# Altium schematic sheet mcu_v1.SchDoc(mcu_v1)
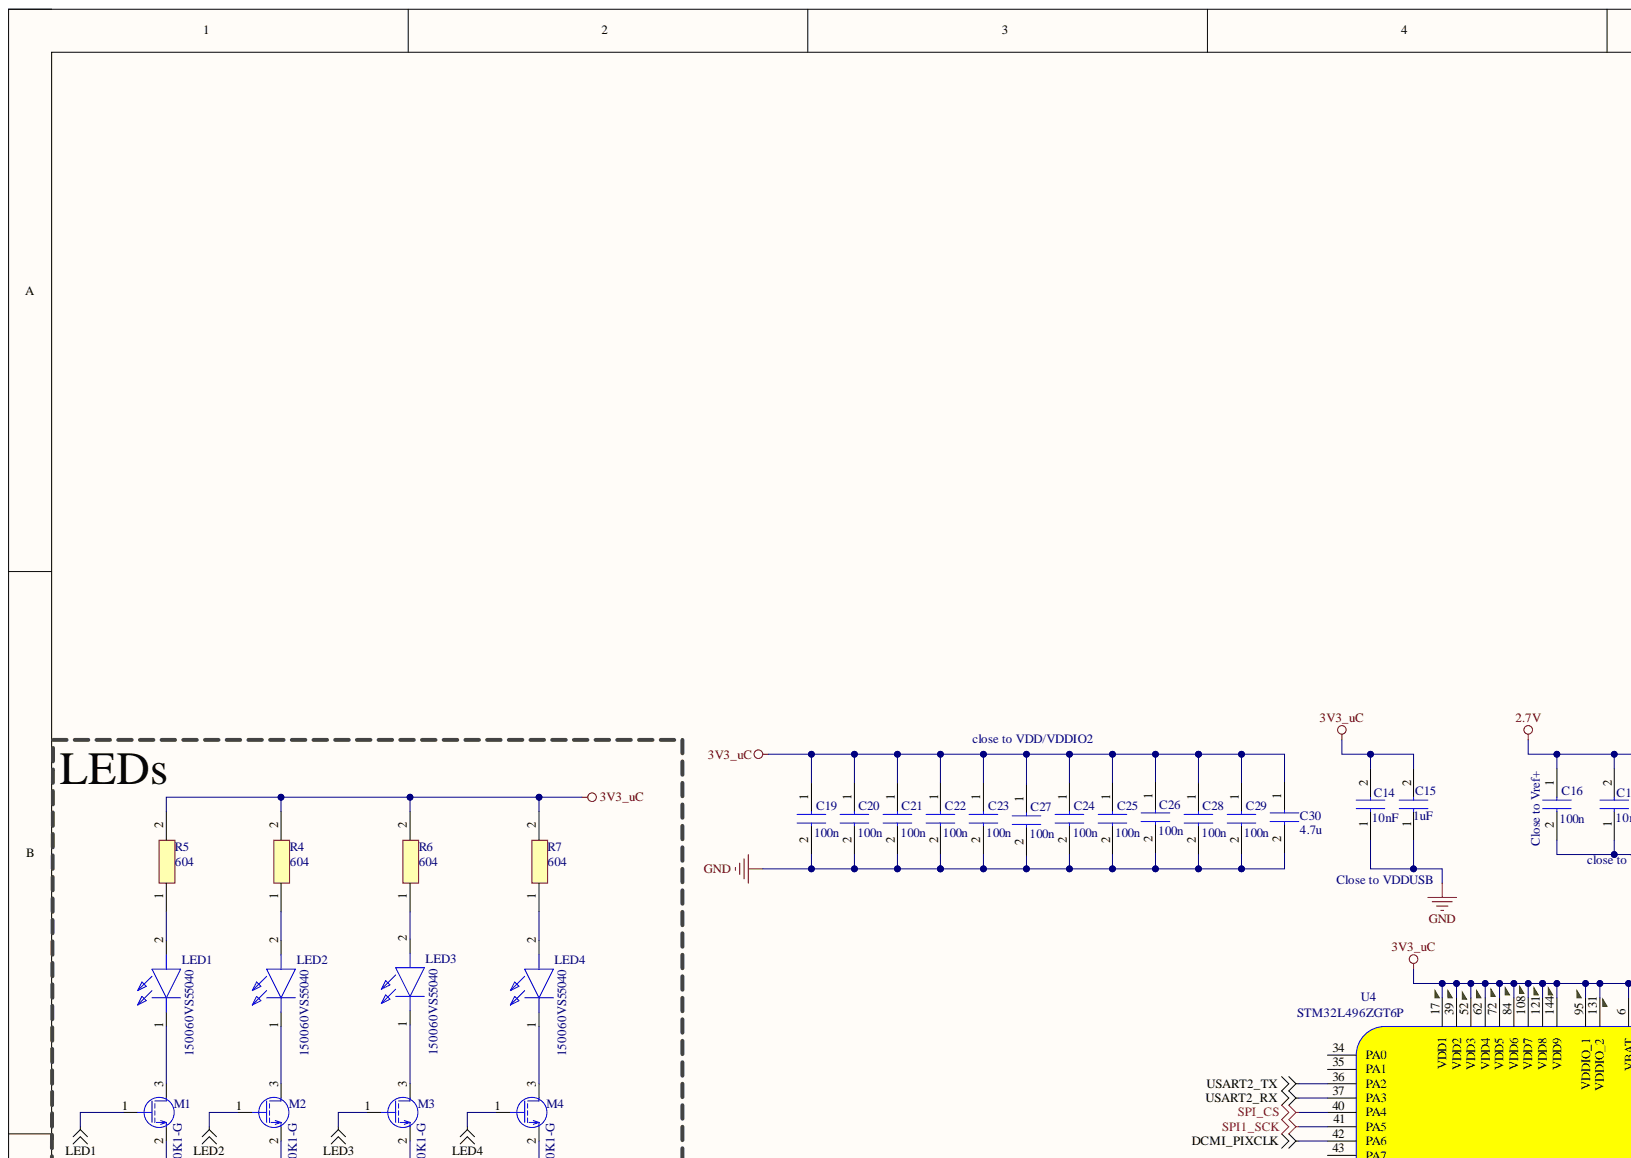
[diagram p0: mcu_v1.SchDoc, top left]
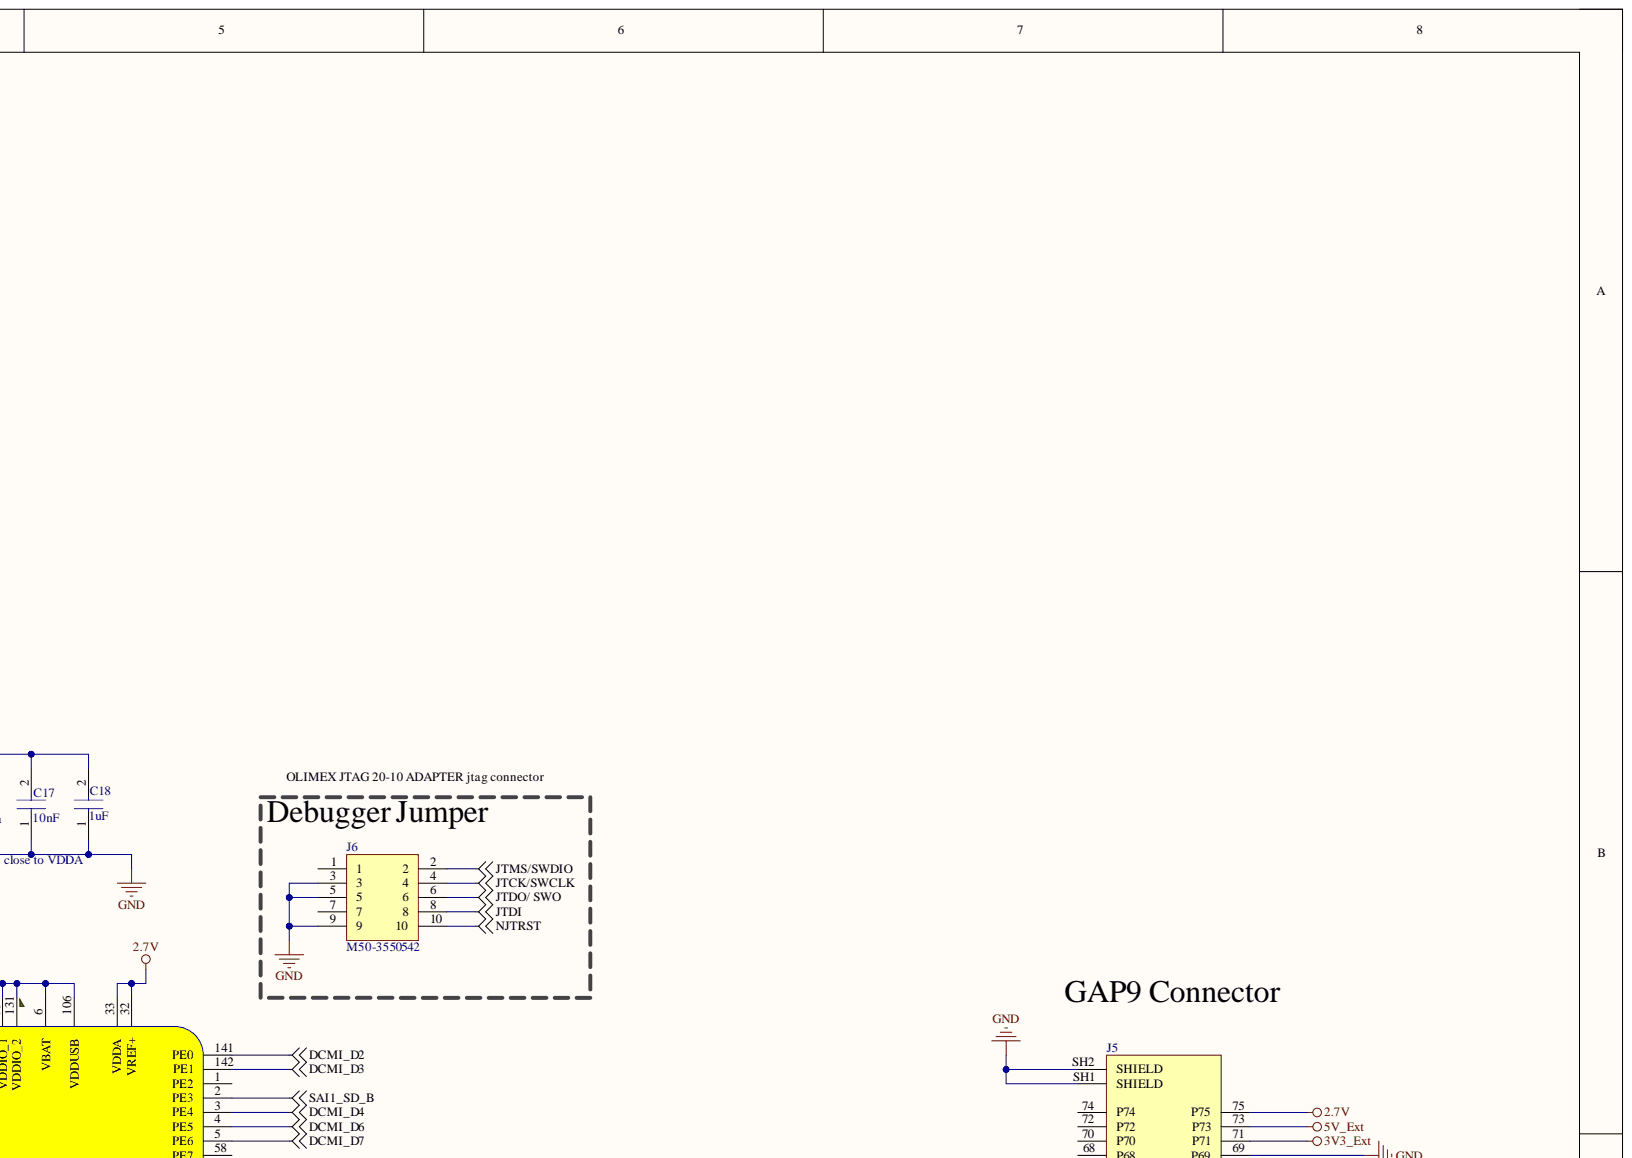
[diagram p1: mcu_v1.SchDoc, top right]
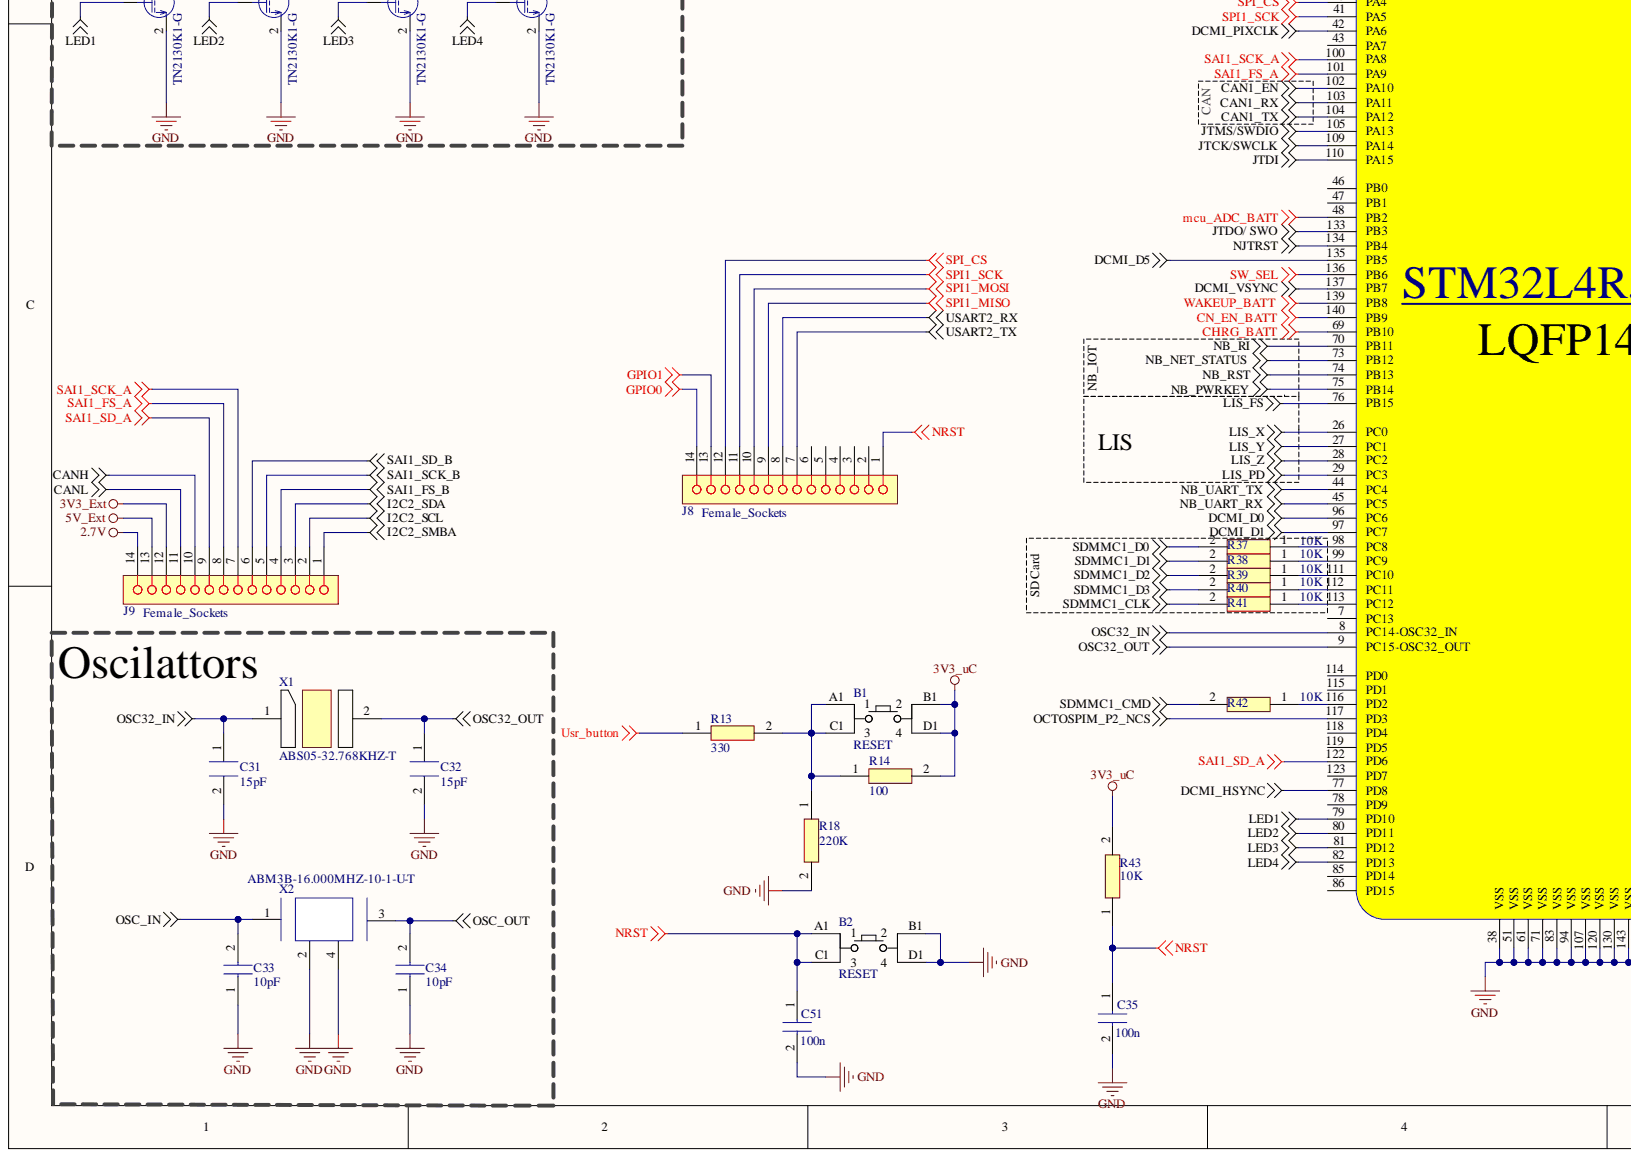
[diagram p2: mcu_v1.SchDoc, bottom left]
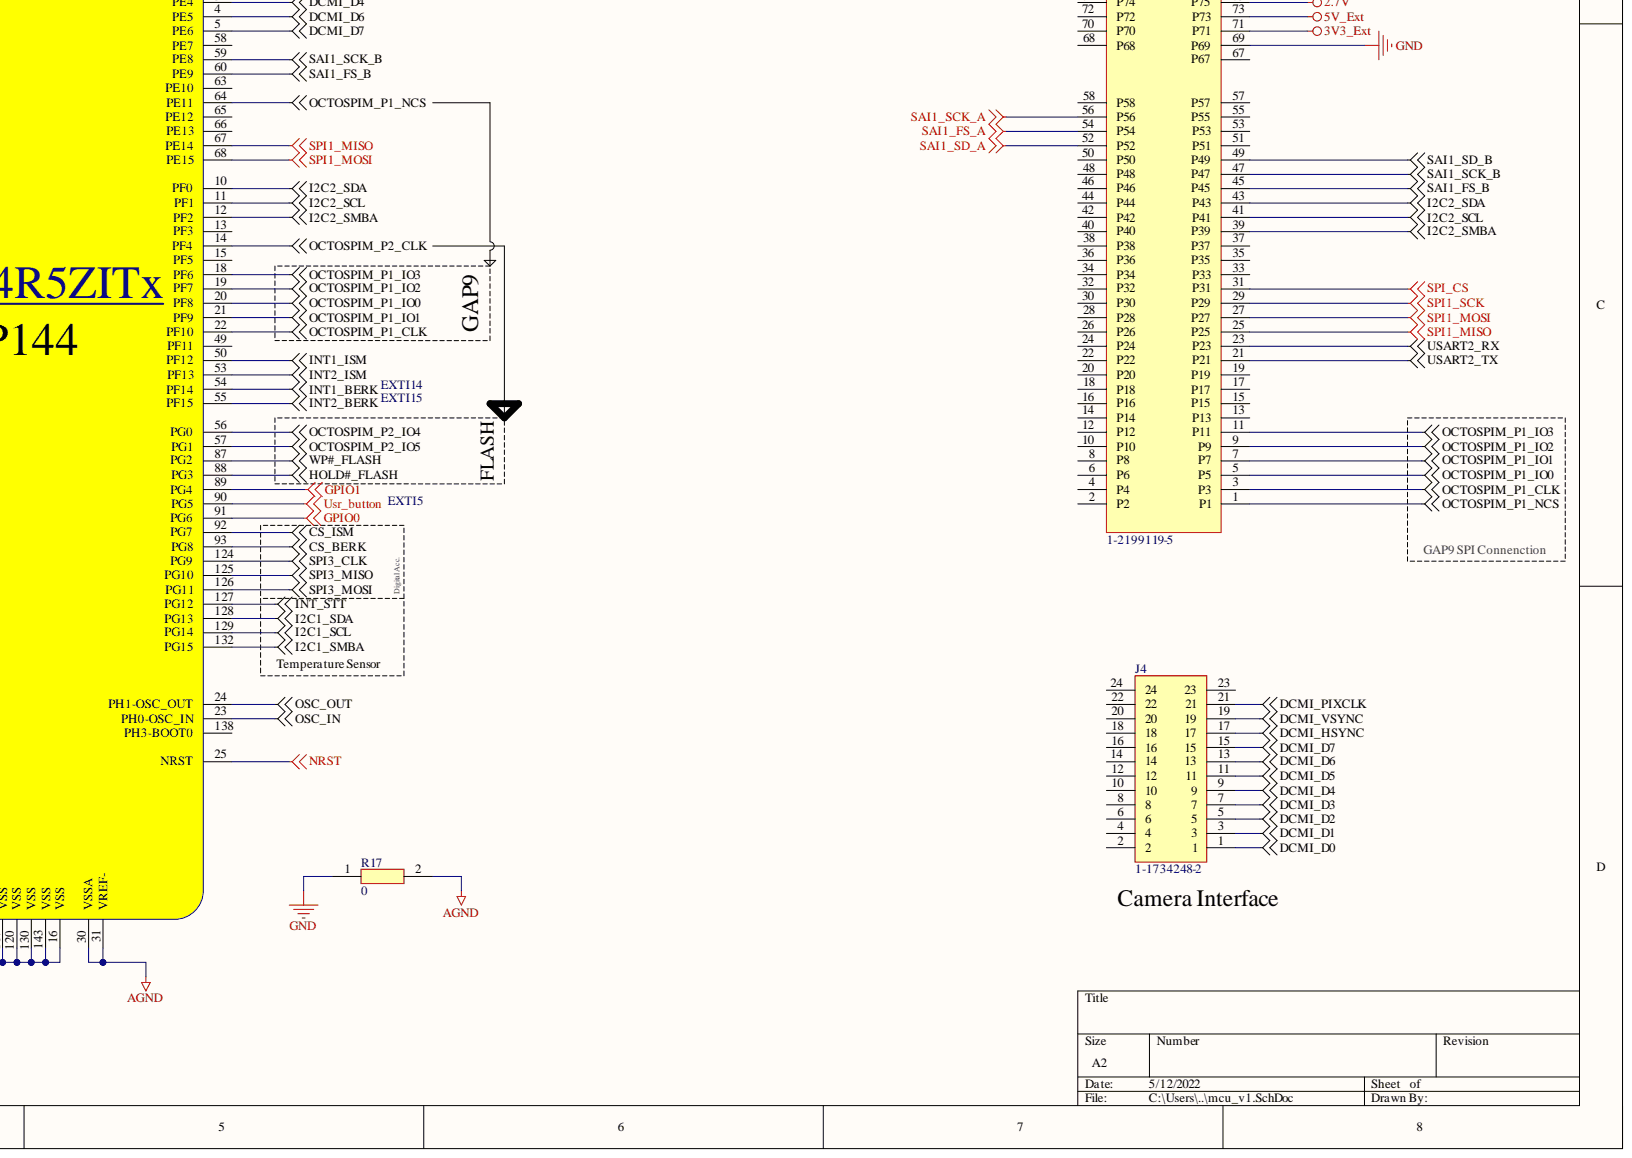
[diagram p3: mcu_v1.SchDoc, bottom right]

## Parts
B1  RESET  [PTS645SM43JSMTR92LFS]
B2  RESET  [PTS645SM43JSMTR92LFS]
C14  10nF  [CAPC1608X87N]
C15  1uF  [CAPC2012X100N]
C16  100n  [CAPC1608X87N]
C17  10nF  [CAPC1608X87N]
C18  1uF  [CAPC2012X100N]
C19  100n  [CAPC1608X87N]
C20  100n  [CAPC1608X87N]
C21  100n  [CAPC1608X87N]
C22  100n  [CAPC1608X87N]
C23  100n  [CAPC1608X87N]
C24  100n  [CAPC1608X87N]
C25  100n  [CAPC1608X87N]
C26  100n  [CAPC1608X87N]
C27  100n  [CAPC1608X87N]
C28  100n  [CAPC1608X87N]
C29  100n  [CAPC1608X87N]
C30  4.7u  [CAPC3216X100N]
C31  15pF  [CAPC1608X87N]
C32  15pF  [CAPC1608X87N]
C33  10pF  [CAPC1608X87N]
C34  10pF  [CAPC1608X87N]
C35  100n  [CAPC1608X87N]
C51  100n  [CAPC1608X87N]
J4  1-1734248-2  [117342482]
J5  1-2199119-5  [TE_1-2199119-5]
J6  M50-3550542  [SHDR10W40P127_2X5_2083X565X1160P]
J8  Female_Sockets  [SAMTEC-BCS-114-X-S-TE]
J9  Female_Sockets  [SAMTEC-BCS-114-X-S-TE]
LED1  150060VS55040  [LEDM168X50N]
LED2  150060VS55040  [LEDM168X50N]
LED3  150060VS55040  [LEDM168X50N]
LED4  150060VS55040  [LEDM168X50N]
M1  TN2130K1-G  [SOT95P237X112-3N]
M2  TN2130K1-G  [SOT95P237X112-3N]
M3  TN2130K1-G  [SOT95P237X112-3N]
M4  TN2130K1-G  [SOT95P237X112-3N]
R4  604  [RESC1608X55N]
R5  604  [RESC1608X55N]
R6  604  [RESC1608X55N]
R7  604  [RESC1608X55N]
R13  330  [RESC1608X55N]
R14  100  [PHPA2512E1001BS]
R17  0  [RESC1608X55N]
R18  220K  [ERJXG_(01005)]
R37  10K  [ERJ3EK_(0603)]
R38  10K  [ERJ3EK_(0603)]
R39  10K  [ERJ3EK_(0603)]
R40  10K  [ERJ3EK_(0603)]
R41  10K  [ERJ3EK_(0603)]
R42  10K  [ERJ3EK_(0603)]
R43  10K  [ERJ3EK_(0603)]
U4  STM32L496ZGT6P  [QFP50P2200X2200X160-144N]
X1  ABS05-32.768KHZ-T  [ABS0532768KHZT]
X2  ABM3B-16.000MHZ-10-1-U-T  Load Capacitance: 10pF  [OSCCC500X320X110-4N]

## Nets
2.7V: C16.1 C17.2 C18.2 J5.75(P75) J9.14 U4.32(VREF+) U4.33(VDDA)
3V3_Ext: J5.71(P71) J9.12
3V3_uC: B1.B1 B1.D1 C14.2 C15.2 C19.1 C20.1 C21.1 C22.1 C23.1 C24.1 C25.1 C26.1 C27.1 C28.1 C29.1 C30.1 R4.2 R5.2 R6.2 R7.2 R14.2 R43.2 U4.6(VBAT) U4.17(VDD1) U4.39(VDD2) U4.52(VDD3) U4.62(VDD4) U4.72(VDD5) U4.84(VDD6) U4.95(VDDIO_1) U4.106(VDDUSB) U4.108(VDD7) U4.121(VDD8) U4.131(VDDIO_2) U4.144(VDD9)
5V_Ext: J5.73(P73) J9.13
AGND: R17.2 U4.30(VSSA) U4.31(VREF-)
CAN1_EN: U4.102(PA10)
CAN1_RX: U4.103(PA11)
CAN1_TX: U4.104(PA12)
CANH: J9.10
CANL: J9.11
CHRG_BATT: U4.69(PB10)
CN_EN_BATT: U4.140(PB9)
CS_BERK: U4.93(PG8)
CS_ISM: U4.92(PG7)
DCMI_D0: J4.1 U4.96(PC6)
DCMI_D1: J4.3 U4.97(PC7)
DCMI_D2: J4.5 U4.141(PE0)
DCMI_D3: J4.7 U4.142(PE1)
DCMI_D4: J4.9 U4.3(PE4)
DCMI_D5: J4.11 U4.135(PB5)
DCMI_D6: J4.13 U4.4(PE5)
DCMI_D7: J4.15 U4.5(PE6)
DCMI_HSYNC: J4.17 U4.77(PD8)
DCMI_PIXCLK: J4.21 U4.42(PA6)
DCMI_VSYNC: J4.19 U4.137(PB7)
GND: B2.B1 B2.D1 C14.1 C15.1 C16.2 C17.1 C18.1 C19.2 C20.2 C21.2 C22.2 C23.2 C24.2 C25.2 C26.2 C27.2 C28.2 C29.2 C30.2 C31.2 C32.2 C33.1 C34.1 C35.2 C51.2 J5.69(P69) J5.SH1(SHIELD) J5.SH2(SHIELD) J6.3 J6.5 J6.9 M1.2 M2.2 M3.2 M4.2 R17.1 R18.2 U4.16(VSS) U4.38(VSS) U4.51(VSS) U4.61(VSS) U4.71(VSS) U4.83(VSS) U4.94(VSS) U4.107(VSS) U4.120(VSS) U4.130(VSS) U4.143(VSS) X2.2 X2.4
GPIO0: J8.14 U4.91(PG6)
GPIO1: J8.13 U4.89(PG4)
HOLD#_FLASH: U4.88(PG3)
I2C1_SCL: U4.129(PG14)
I2C1_SDA: U4.128(PG13)
I2C1_SMBA: U4.132(PG15)
I2C2_SCL: J5.41(P41) J9.2 U4.11(PF1)
I2C2_SDA: J5.43(P43) J9.3 U4.10(PF0)
I2C2_SMBA: J5.39(P39) J9.1 U4.12(PF2)
INT1_BERK: U4.54(PF14)
INT1_ISM: U4.50(PF12)
INT2_BERK: U4.55(PF15)
INT2_ISM: U4.53(PF13)
INT_STT: U4.127(PG12)
JTCK/SWCLK: J6.4 U4.109(PA14)
JTDI: J6.8 U4.110(PA15)
JTDO/ SWO: J6.6 U4.133(PB3)
JTMS/SWDIO: J6.2 U4.105(PA13)
LED1: M1.1 U4.79(PD10)
LED2: M2.1 U4.80(PD11)
LED3: M3.1 U4.81(PD12)
LED4: M4.1 U4.82(PD13)
LIS_FS: U4.76(PB15)
LIS_PD: U4.29(PC3)
LIS_X: U4.26(PC0)
LIS_Y: U4.27(PC1)
LIS_Z: U4.28(PC2)
mcu_ADC_BATT: U4.48(PB2)
NB_NET_STATUS: U4.73(PB12)
NB_PWRKEY: U4.75(PB14)
NB_RI: U4.70(PB11)
NB_RST: U4.74(PB13)
NB_UART_RX: U4.45(PC5)
NB_UART_TX: U4.44(PC4)
NJTRST: J6.10 U4.134(PB4)
NRST: B2.A1 B2.C1 C35.1 C51.1 J8.1 R43.1 U4.25(NRST)
OCTOSPIM_P1_CLK: J5.3(P3) U4.22(PF10)
OCTOSPIM_P1_IO0: J5.5(P5) U4.20(PF8)
OCTOSPIM_P1_IO1: J5.7(P7) U4.21(PF9)
OCTOSPIM_P1_IO2: J5.9(P9) U4.19(PF7)
OCTOSPIM_P1_IO3: J5.11(P11) U4.18(PF6)
OCTOSPIM_P1_NCS: J5.1(P1) U4.64(PE11)
OCTOSPIM_P2_CLK: U4.14(PF4)
OCTOSPIM_P2_IO4: U4.56(PG0)
OCTOSPIM_P2_IO5: U4.57(PG1)
OCTOSPIM_P2_NCS: U4.117(PD3)
OSC32_IN: C31.1 U4.8(PC14-OSC32_IN) X1.1
OSC32_OUT: C32.1 U4.9(PC15-OSC32_OUT) X1.2
OSC_IN: C33.2 U4.23(PH0-OSC_IN) X2.1
OSC_OUT: C34.2 U4.24(PH1-OSC_OUT) X2.3
SAI1_FS_A: J5.54(P54) J9.8 U4.101(PA9)
SAI1_FS_B: J5.45(P45) J9.4 U4.60(PE9)
SAI1_SCK_A: J5.56(P56) J9.7 U4.100(PA8)
SAI1_SCK_B: J5.47(P47) J9.5 U4.59(PE8)
SAI1_SD_A: J5.52(P52) J9.9 U4.122(PD6)
SAI1_SD_B: J5.49(P49) J9.6 U4.2(PE3)
SDMMC1_CLK: R41.2
SDMMC1_CMD: R42.2
SDMMC1_D0: R37.2
SDMMC1_D1: R38.2
SDMMC1_D2: R39.2
SDMMC1_D3: R40.2
SPI1_MISO: J5.25(P25) J8.9 U4.67(PE14)
SPI1_MOSI: J5.27(P27) J8.10 U4.68(PE15)
SPI1_SCK: J5.29(P29) J8.11 U4.41(PA5)
SPI3_CLK: U4.124(PG9)
SPI3_MISO: U4.125(PG10)
SPI3_MOSI: U4.126(PG11)
SPI_CS: J5.31(P31) J8.12 U4.40(PA4)
SW_SEL: U4.136(PB6)
USART2_RX: J5.23(P23) J8.8 U4.37(PA3)
USART2_TX: J5.21(P21) J8.7 U4.36(PA2)
Usr_button: R13.1 U4.90(PG5)
WAKEUP_BATT: U4.139(PB8)
WP#_FLASH: U4.87(PG2)
NetB1_A1: B1.A1 B1.C1 R13.2 R14.1 R18.1
NetLED1_1: LED1.1 M1.3
NetLED1_2: LED1.2 R5.1
NetLED2_1: LED2.1 M2.3
NetLED2_2: LED2.2 R4.1
NetLED3_1: LED3.1 M3.3
NetLED3_2: LED3.2 R6.1
NetLED4_1: LED4.1 M4.3
NetLED4_2: LED4.2 R7.1
NetR37_1: R37.1 U4.98(PC8)
NetR38_1: R38.1 U4.99(PC9)
NetR39_1: R39.1 U4.111(PC10)
NetR40_1: R40.1 U4.112(PC11)
NetR41_1: R41.1 U4.113(PC12)
NetR42_1: R42.1 U4.116(PD2)

Not connected: J4 2 4 6 8 10 12 14 16 18 20 22 23 24; J5 2(P2) 4(P4) 6(P6) 8(P8) 10(P10) 12(P12) 13(P13) 14(P14) 15(P15) 16(P16) 17(P17) 18(P18) 19(P19) 20(P20) 22(P22) 24(P24) 26(P26) 28(P28) 30(P30) 32(P32) 33(P33) 34(P34) 35(P35) 36(P36) 37(P37) 38(P38) 40(P40) 42(P42) 44(P44) 46(P46) 48(P48) 50(P50) 51(P51) 53(P53) 55(P55) 57(P57) 58(P58) 67(P67) 68(P68) 70(P70) 72(P72) 74(P74); J6 1 7; J8 2 3 4 5 6; U4 1(PE2) 7(PC13) 13(PF3) 15(PF5) 34(PA0) 35(PA1) 43(PA7) 46(PB0) 47(PB1) 49(PF11) 58(PE7) 63(PE10) 65(PE12) 66(PE13) 78(PD9) 85(PD14) 86(PD15) 114(PD0) 115(PD1) 118(PD4) 119(PD5) 123(PD7) 138(PH3-BOOT0)

## Library data of the parts
B1 B2 = PTS645SM43JSMTR92LFS: Switch Tactile SPST N.O Round Button 12V 50mA 1.57N Solder Surface Mount T/R; Manufacturer: C&K Components; Component Kind: Standard; ComponentLink1Description: https://datasheet.octopart.com/PTS645SM43JSMTR92LFS-C%26K-Components-datasheet-155912617.pdf?src-supplier=Sager+Electronics; ComponentLink1URL: https://datasheet.octopart.com/PTS645SM43JSMTR92LFS-C%26K-Components-datasheet-155912617.pdf?src-supplier=Sager+Electronics; ComponentLink2Description: https://datasheet.octopart.com/PTS645SM43JSMTR92LFS-C%26K-Components-datasheet-67873720.pdf?src-supplier=Sager+Electronics; ComponentLink2URL: https://datasheet.octopart.com/PTS645SM43JSMTR92LFS-C%26K-Components-datasheet-67873720.pdf?src-supplier=Sager+Electronics; ComponentLink3Description: https://datasheet.octopart.com/PTS645SM43JSMTR92LFS-C%26K-Components-datasheet-150821959.pdf?src-supplier=Sager+Electronics; ComponentLink3URL: https://datasheet.octopart.com/PTS645SM43JSMTR92LFS-C%26K-Components-datasheet-150821959.pdf?src-supplier=Sager+Electronics; Manufacturer Part Number: PTS645SM43JSMTR92LFS; Stock 1: 18999; Supplier 1: Digi-Key; Supplier Part Number 1: 108-PTS645SM43JSMTR92LFSCT-ND; Library Name: shm_schem_lib.SchLib
C14 C17 = 885012206014: Capacitor; Manufacturer_Name: Wurth Elektronik; Component Kind: Standard; Datasheet Link: https://katalog.we-online.com/pbs/datasheet/885012206014.pdf; Height: 0.87mm; Manufacturer_Part_Number: 885012206014
C15 C18 = C0805C105K4RACTU: Capacitor; Manufacturer_Name: Kemet; Component Kind: Standard; Datasheet Link: https://datasheet.datasheetarchive.com/originals/distributors/Datasheets-DGA10/2404873.pdf; Height: 1mm; Manufacturer_Part_Number: C0805C105K4RACTU
C16 C19-C29 C35 C51 = 885012206071: Capacitor; Manufacturer_Name: Wurth Elektronik; Component Kind: Standard; Datasheet Link: https://katalog.we-online.com/pbs/datasheet/885012206071.pdf; Height: 0.87mm; Manufacturer_Part_Number: 885012206071
C30 = C1206C224J1RACAUTO: Capacitor; Manufacturer_Name: Kemet; Component Kind: Standard; Datasheet Link: https://connect.kemet.com:7667/gateway/IntelliData-ComponentDocumentation/1.0/download/specsheet/C1206C224J1RACAUTO; Height: 1mm; Manufacturer_Part_Number: C1206C224J1RACAUTO
C31 C32 = VJ0603A150KXJPW1BC: CAP CER 15PF 16V C0G/NP0 0603; Manufacturer: Vishay Vitramon; Dielectric: C0G; Dielectric Material: Tantalum; Tolerance: 10%; Capacitance: 15pF; Case Code (Imperial): 0603; Case Code (Metric): 1608; Case/Package: 0603; Category: Ceramic Capacitors; Component Kind: Standard; ComponentLink1Description: https://datasheet.ciiva.com/5423/vjw1bcbascomseries-223529-5423350.pdf?src-supplier=Mouser; ComponentLink1URL: https://datasheet.ciiva.com/5423/vjw1bcbascomseries-223529-5423350.pdf?src-supplier=Mouser; ComponentLink2Description: https://datasheet.ciiva.com/16503/vjw1bcbascomseries-16503578.pdf?src-supplier=Future+Electronics; ComponentLink2URL: https://datasheet.ciiva.com/16503/vjw1bcbascomseries-16503578.pdf?src-supplier=Future+Electronics; Construction: Flat; Depth: 0.8mm; Height: 0.95mm; Lead Free: Lead Free; Length: 1.6mm; Manufacturer Part Number: VJ0603A150KXJPW1BC; Max Operating Temperature: 125°C; Min Operating Temperature: -55°C; Mount: Surface Mount; Package Quantity: 15000; Packaging: Tape and Reel; RoHS: Yes; RoHS Compliant: Yes; Series: VJ W1BC; Stock 1: 13534; Supplier 1: Digi-Key; Supplier Part Number 1: 720-VJ0603A150KXJPW1BCCT-ND; Termination: SMD/SMT; Voltage Rating: 16V; Voltage Rating (DC): 16V; Width: 0.8mm; Library Name: shm_schem_lib.SchLib
C33 C34 = C0603C100K4GACTU: Capacitor; Manufacturer_Name: Kemet; Component Kind: Standard; Datasheet Link: https://componentsearchengine.com//C0603C100K4GACTU.pdf; Height: 0.87mm; Manufacturer_Part_Number: C0603C100K4GACTU; Mouser2 Part Number: 80-C0603C100K4GACTU; Mouser2 Price/Stock: https://www.mouser.com/Search/Refine.aspx?Keyword=80-C0603C100K4GACTU
J4 = 1-1734248-2: Connector; Manufacturer_Name: TE Connectivity; Component Kind: Standard; Datasheet Link: https://componentsearchengine.com/Datasheets/2/1-1734248-2.pdf; Height: 8mm; Manufacturer_Part_Number: 1-1734248-2
J5 = 1-2199119-5: 67 Position Female Connector M.2 _NGFF_ Mini Card Gold 0.020 _0.50mm_ Black; MF: TE Connectivity; Component Kind: Standard; Digi-Key_Part_Number: A115902CT-ND; Digi-Key_Purchase_URL: https://www.digikey.com/product-detail/en/te-connectivity-amp-connectors/1-2199119-5/A115902CT-ND/4208910?utm_source=snapeda&utm_medium=aggregator&utm_campaign=symbol; MP: 1-2199119-5; Package: None; SnapEDA_Link: https://www.snapeda.com/parts/1-2199119-5/TE%20Connectivity%20AMP%20Connectors/view-part/2754473/?ref=snap; TE_purchase_URL: https://www.te.com/usa-en/product-1-2199119-5.html?te_bu=Cor&te_type=disp&te_campaign=seda_glo_cor-seda-global-disp-prtnr-fy19-seda-model-bom-cta_sma-317_1&elqCampaignId=32493; Library Name: gap_connector.SchLib
J6 = M50-3550542: Connector; Manufacturer_Name: Harwin; Component Kind: Standard; Datasheet Link: https://componentsearchengine.com/Datasheets/2/M50-3550542.pdf; Height: 11.6mm; Manufacturer_Part_Number: M50-3550542
J8 J9 = BCS-114-L-S-TE: Conn Socket Strip SKT 14 POS 2.54mm Solder ST Top Entry Thru-Hole TIGER CLAW™ Tube; Manufacturer: Samtec; Connector Type: Receptacle; Contact Resistance: 10mR; Max Current Rating: 5.9A; Max Voltage Rating (AC): 475V; Number of Contacts: 14; Category: Connectors; Color: Black; Component Kind: Standard; ComponentLink1Description: https://datasheet.ciiva.com/25617/0900766b8115c15c-25617469.pdf?src-supplier=RSComponents; ComponentLink1URL: https://datasheet.ciiva.com/25617/0900766b8115c15c-25617469.pdf?src-supplier=RSComponents; ComponentLink2Description: https://datasheet.ciiva.com/413/bcs-1xx-xxx-x-xx-xxx-mkt-413700.pdf?src-supplier=Digi-Key; ComponentLink2URL: https://datasheet.ciiva.com/413/bcs-1xx-xxx-x-xx-xxx-mkt-413700.pdf?src-supplier=Digi-Key; ComponentLink3Description: https://datasheet.ciiva.com/10044/bcs-10044566.pdf?src-supplier=Avnet; ComponentLink3URL: https://datasheet.ciiva.com/10044/bcs-10044566.pdf?src-supplier=Avnet; ComponentLink4Description: https://datasheet.ciiva.com/7997/81213-7997401.pdf?src-supplier=Element14; ComponentLink4URL: https://datasheet.ciiva.com/7997/81213-7997401.pdf?src-supplier=Element14; Contact Material: Bronze; Contact Plating: Gold; Depth: 2.54mm; Gender: Receptacle; Housing Color: Black; Housing Material: Polymer; Lead Free: Lead Free; Length: 35.56mm; Manufacturer Part Number: BCS-114-L-S-TE; Max Operating Temperature: 125°C; Min Operating Temperature: -55°C; Mount: Through Hole; Number of Positions: 14; Number of Rows: 1; Orientation: Vertical; Package Quantity: 1; Packaging: Bulk; Pitch: 2.54mm; Radiation Hardening: No; REACH SVHC: No SVHC; RoHS: Yes; RoHS Compliant: Yes; Series: Tiger Claw™ BCS; Stock 1: 1; Supplier 1: RSComponents; Supplier Part Number 1: 7666525; Termination: Solder; Voltage Rating: 475V; Library Name: shm_schem_lib.SchLib
LED1-LED4 = 150060VS55040: LED; Manufacturer_Name: Wurth Elektronik; Component Kind: Standard; Datasheet Link: https://katalog.we-online.com/led/datasheet/150060VS55040.pdf; Height: 0.5mm; Manufacturer_Part_Number: 150060VS55040
M1-M4 = TN2130K1-G: Mosfet, N-Channel Enhancement-Mode, 300V, 25 Ohm Rohs Compliant: Yes; Manufacturer: Microchip Supertex; Continuous Drain Current (ID): 85mA; Drain to Source Resistance: 25R; Element Configuration: Single; Fall Time: 7ns; Gate to Source Voltage (Vgs): 20V; Number of Elements: 1; Power Dissipation: 360mW; Turn-Off Delay Time: 12ns; Case/Package: SOT-23; Category: MOSFETs; Component Kind: Standard; ComponentLink1Description: https://datasheet.ciiva.com/30232/616tn2130-30232358.pdf?src-supplier=Onlinecomponents.com; ComponentLink1URL: https://datasheet.ciiva.com/30232/616tn2130-30232358.pdf?src-supplier=Onlinecomponents.com; ComponentLink2Description: https://datasheet.ciiva.com/16232/tn2130-16232280.pdf?src-supplier=Onlinecomponents.com; ComponentLink2URL: https://datasheet.ciiva.com/16232/tn2130-16232280.pdf?src-supplier=Onlinecomponents.com; Drain to Source Breakdown Voltage: 300V; Lead Free: Lead Free; Manufacturer Part Number: TN2130K1-G; Max Operating Temperature: 150°C; Min Operating Temperature: -55°C; Mount: Surface Mount; Number of Pins: 3; Package Quantity: 3000; Packaging: Tape and Reel; Radiation Hardening: No; Rise Time: 7ns; RoHS: Yes; Stock 1: 1445; Supplier 1: Digi-Key; Supplier Part Number 1: TN2130K1-GCT-ND; Library Name: shm_schem_lib.SchLib
R4-R7 = RT0603FRE07604RL: Res Thin Film 0603 604 Ohm 1% 1/10W ±50ppm/°C Molded SMD SMD Paper T/R; Manufacturer: Yageo; Max Power Dissipation: 100mW; Resistance: 604R; Voltage Rating: 75V; Voltage Rating (DC): 50V; Case Code (Imperial): 0603; Case Code (Metric): 1608; Case/Package: 0603; Category: Chip SMD Resistors; Component Kind: Standard; ComponentLink1Description: https://datasheet.ciiva.com/2559/pyu-rt-1-to-0-05-rohs-l-5-2559885.pdf?src-supplier=Digi-Key; ComponentLink1URL: https://datasheet.ciiva.com/2559/pyu-rt-1-to-0-05-rohs-l-5-2559885.pdf?src-supplier=Digi-Key; ComponentLink2Description: https://datasheet.ciiva.com/8885/pyu-rt-1-to-0-05-rohs-l-4-8885229.pdf?src-supplier=Avnet; ComponentLink2URL: https://datasheet.ciiva.com/8885/pyu-rt-1-to-0-05-rohs-l-4-8885229.pdf?src-supplier=Avnet; Construction: Rectangular; Contact Plating: Tin,Matte; Depth: 0.8mm; Height: 0.022inch; Lead Free: Lead Free; Length: 0.063inch; Manufacturer Part Number: RT0603FRE07604RL; Max Operating Temperature: 125°C; Military Standard: Not; Min Operating Temperature: -55°C; Number of Terminations: 2; Package Quantity: 5000; Packaging: Tape and Reel; Power Rating: 100mW; Radiation Hardening: No; Resistor Type: Thin Film; RoHS: Yes; RoHS Compliant: Yes; Series: RT; Stock 1: 6284; Supplier 1: Digi-Key; Supplier Part Number 1: 13-RT0603FRE07604RLCT-ND; Temperature Coefficient: 50ppm/°C; Termination: SMD/SMT; Tolerance: 1%; Width: 0.031inch; Library Name: shm_schem_lib.SchLib
R13 = CMP0603AFX-3300ELF: Resistor; Manufacturer_Name: Bourns; Component Kind: Standard; Datasheet Link: https://www.bourns.com/docs/Product-Datasheets/CMP-A.pdf; Height: 0.55mm; Manufacturer_Part_Number: CMP0603AFX-3300ELF
R14 = PHPA2512E1000BST1: Resistor; Manufacturer_Name: Vishay; Component Kind: Standard; Datasheet Link: https://www.vishay.com/docs/60160/phpa.pdf; Height: 0.508mm; Manufacturer_Part_Number: PHPA2512E1000BST1
R17 = RC0603FR-070RL: Resistor; Manufacturer_Name: YAGEO (PHYCOMP); Component Kind: Standard; Height: 0.55mm; Manufacturer_Part_Number: RC0603FR-070RL
R18 = ERJ-XGNJ224Y: Resistor; Manufacturer_Name: Panasonic; Component Kind: Standard; Datasheet Link: https://industrial.panasonic.com/cdbs/www-data/pdf/RDA0000/AOA0000C301.pdf; Height: 0.15mm; Manufacturer_Part_Number: ERJ-XGNJ224Y
R37-R43 = ERJ-3EKF1002V: Resistor; Manufacturer_Name: Panasonic; Component Kind: Standard; Datasheet Link: https://componentsearchengine.com//ERJ-3EKF1002V.pdf; Height: 0.55mm; Manufacturer_Part_Number: ERJ-3EKF1002V; Mouser2 Part Number: 667-ERJ-3EKF1002V; Mouser2 Price/Stock: https://www.mouser.com/Search/Refine.aspx?Keyword=667-ERJ-3EKF1002V
U4 = STM32L496ZGT6P: Ultra-low-power with FPU ARM Cortex-M4 MCU 80 MHz with 1 Mbyte Flash, USB OTG, LCD, DFSDM; Manufacturer: STMicroelectronics; Frequency: 80MHz; Interface: I2C,SPI,USB,IrDA,MMC,SD,UART,USART,EBI/EMI; Memory Size: 1MB; Number of I/Os: 115; Oscillator Type: Internal; Peripherals: Brown-out Detect/Reset,PWM,WDT,DMA,LCD; Case/Package: LQFP; Category: Microcontrollers; Component Kind: Standard; ComponentLink1Description: http://www.farnell.com/datasheets/2602789.pdf?src-supplier=Farnell; ComponentLink1URL: http://www.farnell.com/datasheets/2602789.pdf?src-supplier=Farnell; ComponentLink2Description: http://www.st.com/content/ccc/resource/technical/document/datasheet/group3/3f/73/19/d1/d4/9e/48/34/DM00284211/files/DM00284211.pdf/jcr:content/translations/en.DM00284211.pdf?src-supplier=Digi-Key; ComponentLink2URL: http://www.st.com/content/ccc/resource/technical/document/datasheet/group3/3f/73/19/d1/d4/9e/48/34/DM00284211/files/DM00284211.pdf/jcr:content/translations/en.DM00284211.pdf?src-supplier=Digi-Key; ComponentLink3Description: http://www.farnell.com/datasheets/2602789.pdf?src-supplier=Newark; ComponentLink3URL: http://www.farnell.com/datasheets/2602789.pdf?src-supplier=Newark; Core Architecture: ARM; Manufacturer Part Number: STM32L496ZGT6P; Max Operating Temperature: 85°C; Memory Type: FLASH; Min Operating Temperature: -40°C; Packaging: Tray; RoHS: Yes; Supplier 1: Digi-Key; Supplier Part Number 1: 497-17999-ND; Library Name: shm_schem_lib.SchLib
X1 = ABS05-32.768KHZ-T: ABRACON - ABS05-32.768KHZ-T - Crystal, 32.768 kHz, SMD, 1.6mm x 1mm, 12.5 pF, 20 ppm, ABS05 Series; ESR (Equivalent Series Resistance): 90kR; Frequency Tolerance: 20ppm; Load Capacitance: 12.5pF; Case/Package: SMD/SMT; Category: Crystals; Component Kind: Standard; ComponentLink1Description: https://datasheet.ciiva.com/23810/abs05-23810480.pdf?src-supplier=Newark; ComponentLink1URL: https://datasheet.ciiva.com/23810/abs05-23810480.pdf?src-supplier=Newark; ComponentLink2Description: https://datasheet.ciiva.com/6728/abs05-6728949.pdf?src-supplier=Digi-Key; ComponentLink2URL: https://datasheet.ciiva.com/6728/abs05-6728949.pdf?src-supplier=Digi-Key; ComponentLink3Description: https://datasheet.ciiva.com/6728/abs05-6728950.pdf?src-supplier=Digi-Key; ComponentLink3URL: https://datasheet.ciiva.com/6728/abs05-6728950.pdf?src-supplier=Digi-Key; ComponentLink4Description: https://datasheet.ciiva.com/16411/abs05-16411149.pdf?src-supplier=Future+Electronics; ComponentLink4URL: https://datasheet.ciiva.com/16411/abs05-16411149.pdf?src-supplier=Future+Electronics; Depth: 1mm; Frequency: 32.768kHz; Height: 0.02inch; Lead Free: Lead Free; Length: 1.6mm; Manufacturer: Abracon; Manufacturer Part Number: ABS05-32.768KHZ-T; Max Operating Temperature: 85°C; Min Operating Temperature: -40°C; Mount: Surface Mount; Number of Pins: 2; Operating Frequency: 32.768 kHz; Package Quantity: 3000; Packaging: Tape and Reel; Radiation Hardening: No; REACH SVHC: No SVHC; RoHS: Yes; RoHS Compliant: Yes; Series: ABS05; Series Resistance: 90kR; Stock 1: 333; Supplier 1: Digi-Key; Supplier Part Number 1: 535-11899-1-ND; Termination: SMD/SMT; Tolerance: 20ppm; Width: 0.039inch; Library Name: shm_schem_lib.SchLib
X2 = ABM3B-16.000MHZ-10-1-U-T: Crystal, Ceramic, 16.000Mhz, 10Pf, 10Ppm-10+60C, 5 X 3.2 X 1 Rohs Compliant: Yes; Manufacturer: Abracon; ESR (Equivalent Series Resistance): 50R; Frequency: 16MHz; Frequency Stability: 10ppm; Case/Package: CSMD; Category: Crystals; Component Kind: Standard; ComponentLink1Description: https://datasheet.ciiva.com/15116/getdatasheetpartid-724423-15116862.pdf?src-supplier=Verical; ComponentLink1URL: https://datasheet.ciiva.com/15116/getdatasheetpartid-724423-15116862.pdf?src-supplier=Verical; ComponentLink2Description: https://datasheet.ciiva.com/16366/abm3b-16366326.pdf?src-supplier=Future+Electronics; ComponentLink2URL: https://datasheet.ciiva.com/16366/abm3b-16366326.pdf?src-supplier=Future+Electronics; ComponentLink3Description: https://datasheet.ciiva.com/862/abm3b-862456.pdf?src-supplier=Digi-Key; ComponentLink3URL: https://datasheet.ciiva.com/862/abm3b-862456.pdf?src-supplier=Digi-Key; ComponentLink4Description: https://datasheet.ciiva.com/3175/abm3b-3175821.pdf?src-supplier=Avnet; ComponentLink4URL: https://datasheet.ciiva.com/3175/abm3b-3175821.pdf?src-supplier=Avnet; ComponentLink5Description: https://datasheet.ciiva.com/862/abm3b-862455.pdf?src-supplier=Digi-Key; ComponentLink5URL: https://datasheet.ciiva.com/862/abm3b-862455.pdf?src-supplier=Digi-Key; ComponentLink6Description: https://datasheet.ciiva.com/862/abm3b-862457.pdf?src-supplier=Digi-Key; ComponentLink6URL: https://datasheet.ciiva.com/862/abm3b-862457.pdf?src-supplier=Digi-Key; Depth: 3.2mm; Frequency Tolerance: 10ppm; Height: 0.043inch; Lead Free: Lead Free; Length: 5mm; Manufacturer Part Number: ABM3B-16.000MHZ-10-1-U-T; Max Operating Temperature: 60°C; Min Operating Temperature: -10°C; Mount: Surface Mount; Number of Pins: 4; Package Quantity: 1000; Packaging: Tape and Reel; REACH SVHC: No SVHC; Resistor Type: Ceramic; RoHS: Yes; Series Resistance: 50R; Supplier 1: Digi-Key; Supplier Part Number 1: 300-8206-1-ND; Termination: SMD/SMT; Tolerance: 10ppm; Width: 3.2mm; Library Name: shm_schem_lib.SchLib
